# Altium schematic sheet Sheet1.SchDoc(Sheet1)
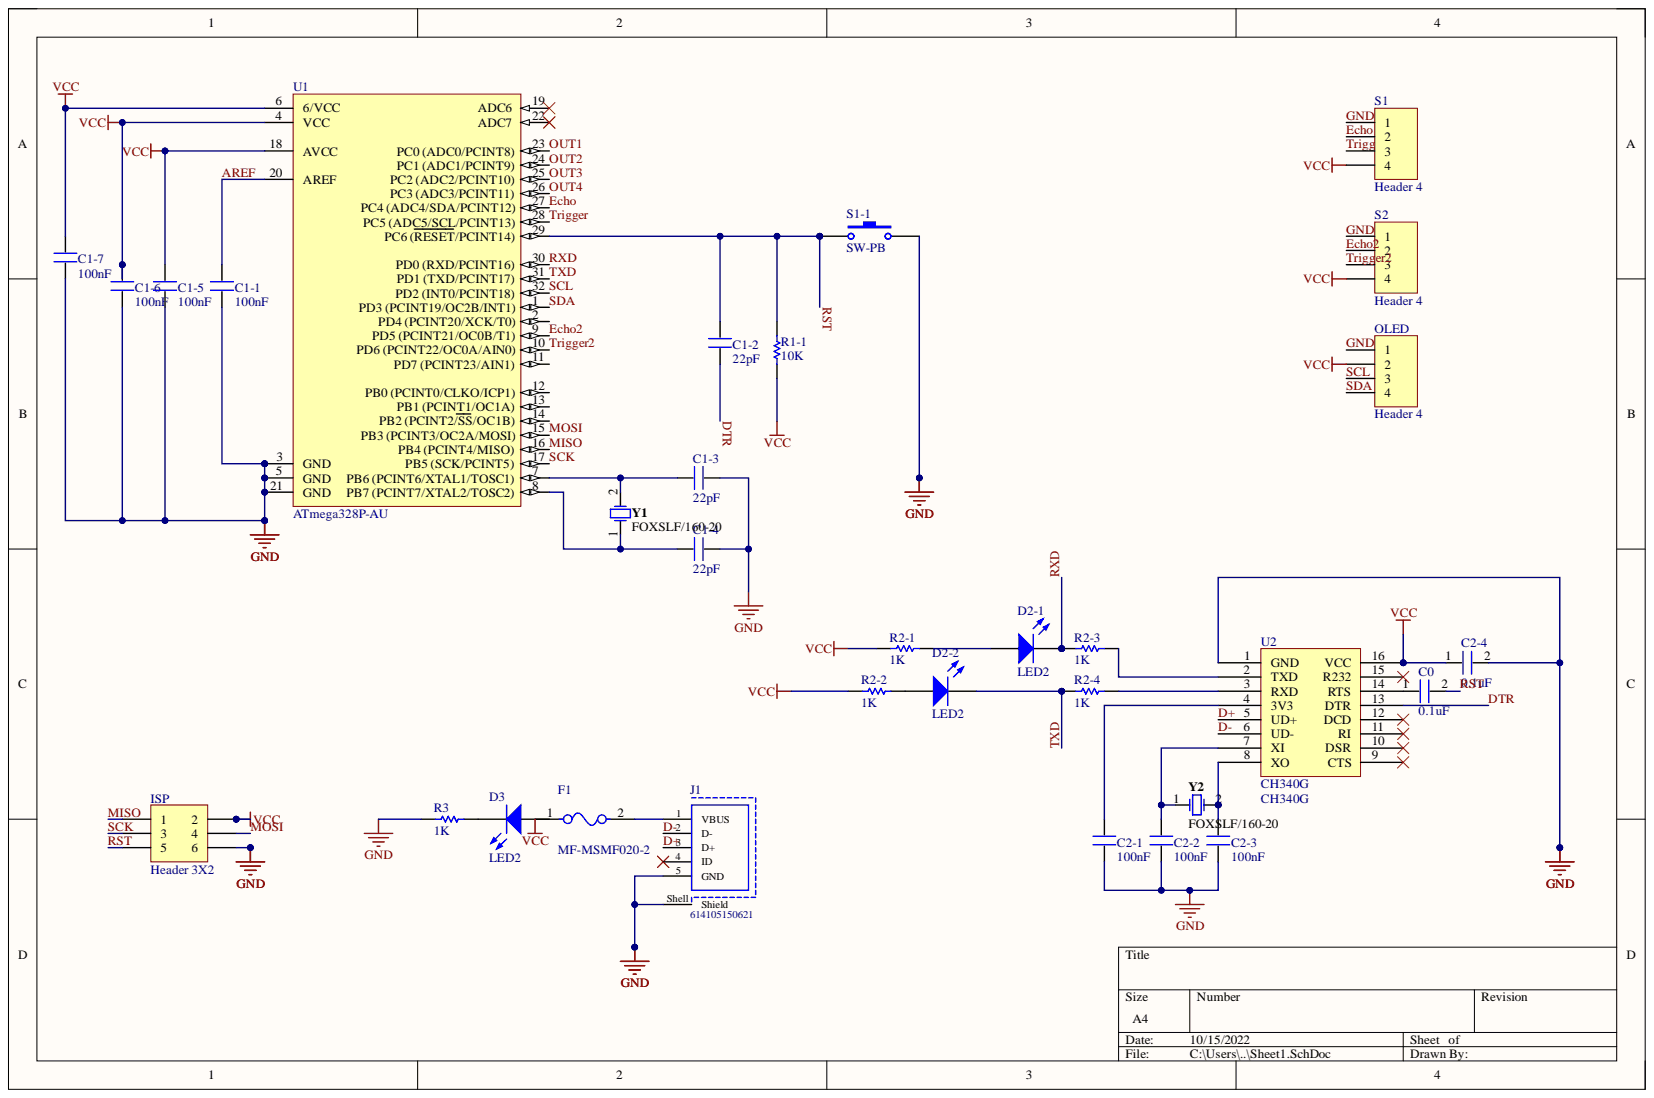
[diagram p0: Sheet1.SchDoc]

## Parts
C0  value 0.1uF  [0805]  (hidden comment: CAP)
C1-1  value 100nF  [0805]  (hidden comment: CAP)
C1-2  value 22pF  [0805]  (hidden comment: CAP)
C1-3  value 22pF  [0805]  (hidden comment: CAP)
C1-4  value 22pF  [0805]  (hidden comment: CAP)
C1-5  value 100nF  [0805]  (hidden comment: CAP)
C1-6  value 100nF  [0805]  (hidden comment: CAP)
C1-7  value 100nF  [0805]  (hidden comment: CAP)
C2-1  value 100nF  [0805]  (hidden comment: CAP)
C2-2  value 100nF  [0805]  (hidden comment: CAP)
C2-3  value 100nF  [0805]  (hidden comment: CAP)
C2-4  value 0.1uF  [0805]  (hidden comment: CAP)
D2-1  LED2  [3.2X1.6X1.1]
D2-2  LED2  [3.2X1.6X1.1]
D3  LED2  [3.2X1.6X1.1]
F1  MF-MSMF020-2  [FP-MF-MSMF020-2-MFG]
ISP  Header 3X2  [HDR2X3]
J1  614105150621  [629105150921]
OLED  Header 4  [HDR1X4]
R1-1  Vaule: 10K  [0805]  (hidden comment: RES)
R2-1  Vaule: 1K  [0805]  (hidden comment: RES)
R2-2  Vaule: 1K  [0805]  (hidden comment: RES)
R2-3  Vaule: 1K  [0805]  (hidden comment: RES)
R2-4  Vaule: 1K  [0805]  (hidden comment: RES)
R3  Vaule: 1K  [0805]  (hidden comment: RES)
S1  Header 4  [HDR1X4]
S1-1  SW-PB  [SPST-2]
S2  Header 4  [HDR1X4]
U1  ATmega328P-AU  [32A_L - duplicate]
U2  CH340G  [SOIC127P600X170-16N]
Y1  FOXSLF/160-20  [FOX-FOXSLF160-20]
Y2  FOXSLF/160-20  [FOX-FOXSLF160-20]

## Nets
VCC: C1-5.2 C1-6.2 C1-7.2 C2-4.1 F1.1 ISP.2 OLED.2 R1-1.2 R2-1.1 R2-2.1 S1.4 S2.4 U1.4(VCC) U1.6(6/VCC) U1.18(AVCC) U2.16(VCC)
AREF: C1-1.2 U1.20(AREF)
D+: J1.3(D+) U2.5(UD+)
D-: J1.2(D-) U2.6(UD-)
DTR: C1-2.1 U2.13(DTR)
Echo: S1.2 U1.27(PC4_(ADC4/SDA/PCINT12))
Echo2: S2.2 U1.9(PD5_(PCINT21/OC0B/T1))
GND: C1-1.1 C1-3.2 C1-4.2 C1-5.1 C1-6.1 C1-7.1 C2-1.1 C2-2.1 C2-3.1 C2-4.2 ISP.6 J1.5(GND) J1.Shell(Shield) OLED.1 R3.1 S1.1 S1-1.2 S2.1 U1.3(GND) U1.5(GND) U1.21(GND) U2.1(GND)
MISO: ISP.1 U1.16(PB4_(PCINT4/MISO))
MOSI: ISP.4 U1.15(PB3_(PCINT3/OC2A/MOSI))
OUT1: U1.23(PC0_(ADC0/PCINT8))
OUT2: U1.24(PC1_(ADC1/PCINT9))
OUT3: U1.25
OUT4: U1.26(PC3_(ADC3/PCINT11))
RST: C0.2 C1-2.2 ISP.5 R1-1.1 S1-1.1 U1.29(PC6_(RESET/PCINT14))
RXD: D2-1.2 R2-3.1 U1.30(PD0_(RXD/PCINT16))
SCK: ISP.3 U1.17(PB5_(SCK/PCINT5))
SCL: OLED.3 U1.32(PD2_(INT0/PCINT18))
SDA: OLED.4 U1.1(PD3_(PCINT19/OC2B/INT1))
Trigger: S1.3 U1.28(PC5_(ADC5/SCL/PCINT13))
Trigger2: S2.3 U1.10(PD6_(PCINT22/OC0A/AIN0))
TXD: D2-2.2 R2-4.1 U1.31(PD1_(TXD/PCINT17))
NetC0_1: C0.1 U2.14(RTS)
NetC1-3_1: C1-3.1 U1.7 Y1.2
NetC1-4_1: C1-4.1 U1.8 Y1.1
NetC2-1_2: C2-1.2 U2.4(3V3)
NetC2-2_2: C2-2.2 U2.7(XI) Y2.1
NetC2-3_2: C2-3.2 U2.8(XO) Y2.2
NetD2-1_1: D2-1.1 R2-1.2
NetD2-2_1: D2-2.1 R2-2.2
NetD3_2: D3.2 R3.2
NetF1_2: F1.2 J1.1(VBUS)
NetR2-3_2: R2-3.2 U2.2(TXD)
NetR2-4_2: R2-4.2 U2.3(RXD)

Not connected: D3 1; J1 4(ID); U1 2(PD4_(PCINT20/XCK/T0)) 11(PD7_(PCINT23/AIN1)) 12(PB0_(PCINT0/CLKO/ICP1)) 13(PB1_(PCINT1/OC1A)) 14(PB2_(PCINT2/SS/OC1B)) 19(ADC6) 22(ADC7); U2 9(CTS) 10(DSR) 11(RI) 12(DCD) 15(R232)

## Library data of the parts
C0 C1-1-C1-7 C2-1-C2-4 = CAP: Capacitor; Component Kind: Standard; Library Name: lib.SchLib
D2-1 D2-2 D3 = LED2: Typical RED, GREEN, YELLOW, AMBER GaAs LED; Component Kind: Standard; LatestRevisionDate: 17-Jul-2002; LatestRevisionNote: Re-released for DXP Platform.; Library Name: lib.SchLib; PackageDescription: SM LED; 2 Flat Leads; PackageReference: 3.2X1.6X1.1; PackageVersion: Feb-2002; Published: 8-Jun-2000; Simulation: LED2; Publisher: Altium Limited
F1 = CMP-07400-000027-1: PTC RESET FUSE 30V 200MA 1812; Manufacturer: Bourns; Automotive Grade: Grade 3; Current Rating: 80A; Hold Current: 0.2A; Resistance: 0.4-6Ω; Trip Current: 0.4A; Trip Time: 0.06s; Value: 0.4-6Ω; Automotive: Yes; Category: Fuse; Component Kind: Standard; ComponentLink2Description: Datasheet; ComponentLink2URL: https://www.bourns.com/docs/Product-Datasheets/mfmsmf.pdf; Device Class L1: Circuit Protection; Device Class L2: PTC Resettable Fuses; Device Class L3: unset; Digikey Description: PTC RESET FUSE 30V 200MA 1812; Height: 1.1mm; Lead Free: Yes; Library Name: lib.SchLib; Manufacturer Part Number: MF-MSMF020-2; Mouser Description: Resettable Fuses - PPTC 0.2A 30V 0.40ohm; Octopart: https://octopart.com/mf-msmf020-2-bourns-19289; Package: MF-MSMF020-2; RoHS: TRUE; Temperature: -40°C to +85°C; Temperature Range High: +85°C; Temperature Range Low: -40°C; Voltage Rating: 30V
ISP = Header 3X2: Header, 3-Pin, Dual row; Component Kind: Standard; LatestRevisionDate: 17-Jul-2002; LatestRevisionNote: Re-released for DXP Platform.; Library Name: Miscellaneous Connectors.IntLib; Signal Integrity: Connector; Publisher: Altium Limited
J1 = CMP-1502-03251-1: Micro USB 2.0 Type AB Receptacle WR-COM, Vertical, THT; Manufacturer: Wurth Elektronik; Contact Resistance: 30mOhm; Rated Current: 1.8A; Working Voltage: 30VAC; Component Kind: Standard; Connector Type: MicroUSB2.0TypeAB; Datasheet URL: https://katalog.we-online.de/em/datasheet/614105150621.pdf; Gender: Receptacle; Library Name: lib.SchLib; Manufacturer URL: http://www.we-online.de; Mounting Technology: THT; Operating Temperature: -40to85degC; Orientation: Vertical; Package Reference: 614105150621; Packaging: TapeandReel; Part Number: 614105150621; Publisher: WurthElektronik
OLED S1 S2 = Header 4: Header, 4-Pin; Component Kind: Standard; LatestRevisionDate: 17-Jul-2002; LatestRevisionNote: Re-released for DXP Platform.; Library Name: Miscellaneous Connectors.IntLib; Signal Integrity: Connector; Publisher: Altium Limited
R1-1 R2-1-R2-4 R3 = RES: Resistor; Component Kind: Standard; Library Name: lib.SchLib
S1-1 = SW-PB: Switch; Component Kind: Standard; LatestRevisionDate: 17-Jul-2002; LatestRevisionNote: Re-released for DXP Platform.; Library Name: lib.SchLib; PackageDescription: Switch; 2 Leads; PackageReference: SPST-2; Published: 24-Mar-1999; Publisher: Altium Limited
U1 = CMP-0095-00269-2: 8-bit AVR Microcontroller, 32KB Flash, 1KB EEPROM, 2KB SRAM, 32-pin TQFP, Industrial Grade (-40°C to 85°C); Code_JEDEC: MS-026-ABA; Component Kind: Standard; ComponentLink1Description: Manufacturer URL; ComponentLink1URL: http://www.atmel.com/; ComponentLink2Description: ATmega48A/48PA/88A/88PA/168A/168PA/328/328P Datasheet; ComponentLink2URL: http://www.atmel.com/dyn/resources/prod_documents/doc8271.pdf; ComponentLink3Description: ATmega48A/48PA/88A/88PA/168A/168PA/328/328P Summary; ComponentLink3URL: http://www.atmel.com/dyn/resources/prod_documents/8271S.pdf; DatasheetVersion: revD, May-2011; Library Name: lib.SchLib; PackageDescription: 32-Lead, 7x7x1.0 mm Body, 0.8mm Lead Pitch, Thin Profile Plastic Quad Flat Package (TQFP); PackageReference: 32A; PackageVersion: revC, Oct-2010; RevisionNote: DatasheetVersion & PackageVersion updated. URLs added.
Y1 Y2 = CMP-1749-00003-1: Resistance Weld Thru-Hole Crystal, 16 MHz, -20 to 70 degC, 2-Pin THD, RoHS, Bulk; Case\Package: Axial; Power Rating: 250 mW; Resistance: 221 kohm; Tolerance: 1%; Voltage Rating: 250 V; Component Kind: Standard; ComponentLink1Description: Manufacturer URL; ComponentLink1URL: http://www.foxonline.com/; ComponentLink2Description: Datasheet; ComponentLink2URL: http://www.foxonline.com/pdfs/hc49slf.pdf; DatasheetVersion: Mar-15; Length: 0.248 inch; Library Name: lib.SchLib; Max Operating Temperature: 155 degC; Min Operating Temperature: -55 degC; Mount: Through Hole; Package Quantity: 200; PackageDescription: 2-Pin Through Hole Device, Body 11.35 x 4.65 mm; PackageReference: FOXSLF160-20; Packaging: Bulk; RoHSCompliant: true
U2 = CH340G: Component Kind: Standard; Library Name: lib.SchLib
